# Altium schematic sheet 13 HDMI&EDP.SchDoc
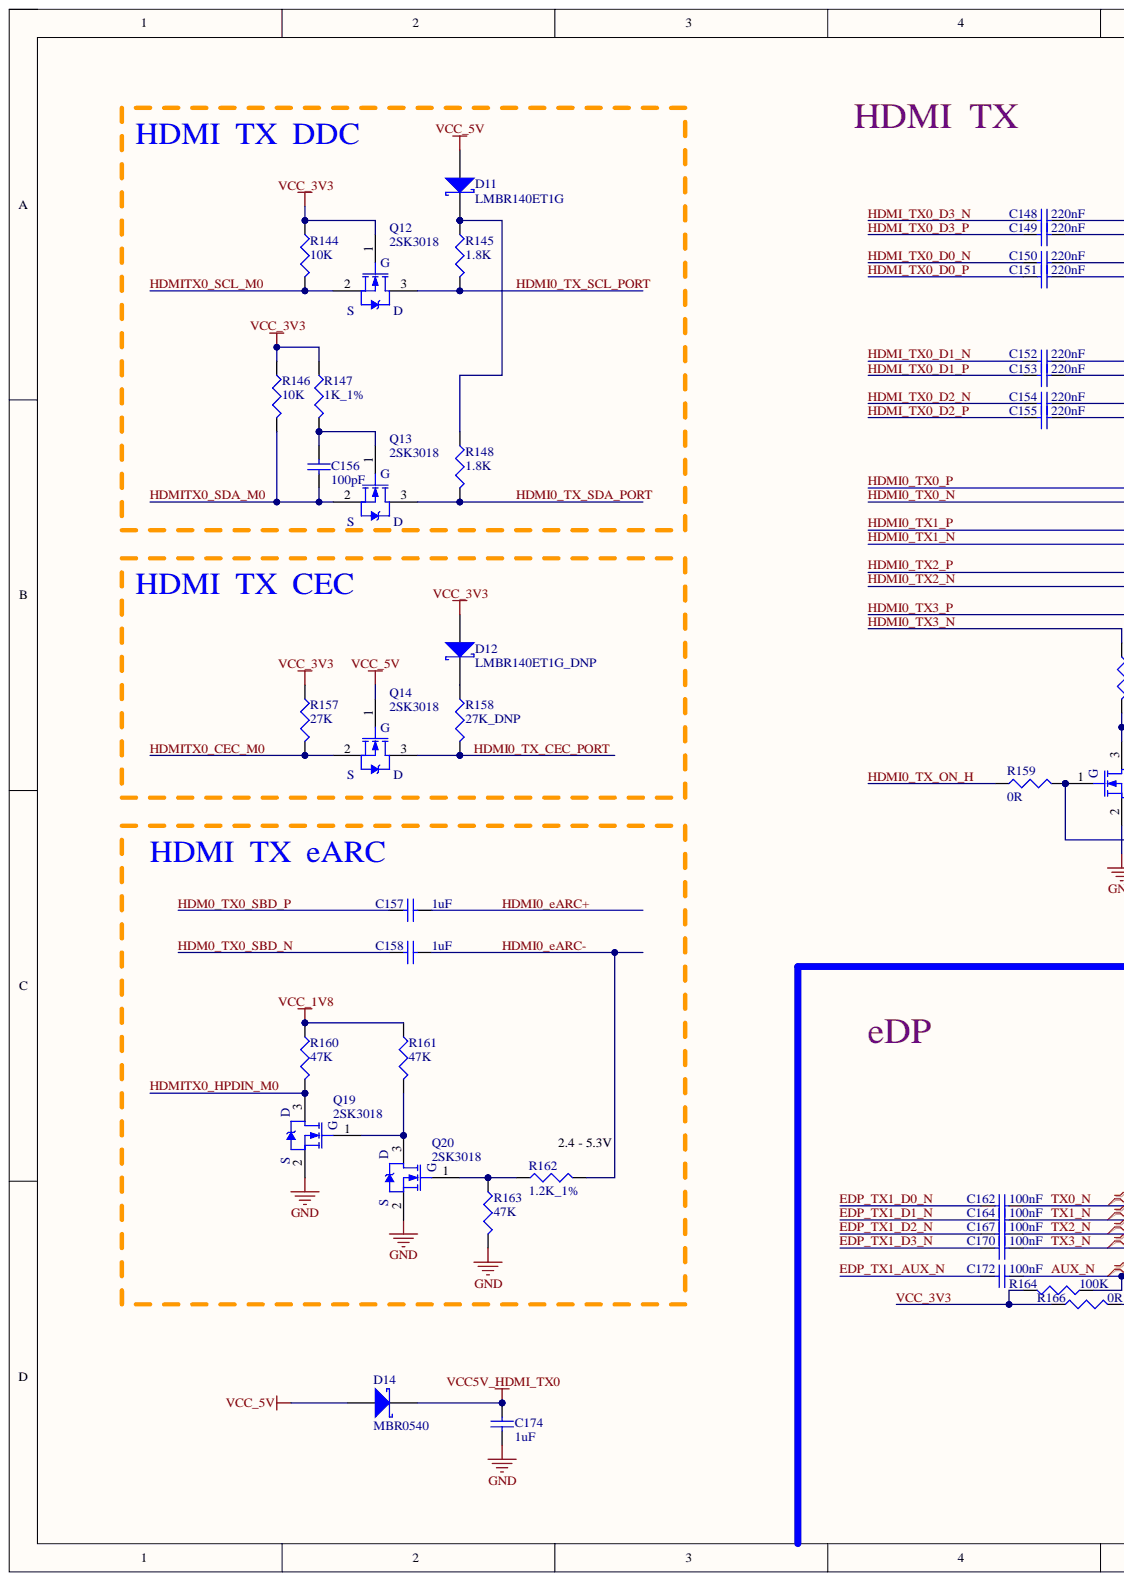
[diagram p0: 13 HDMI&EDP.SchDoc, left]
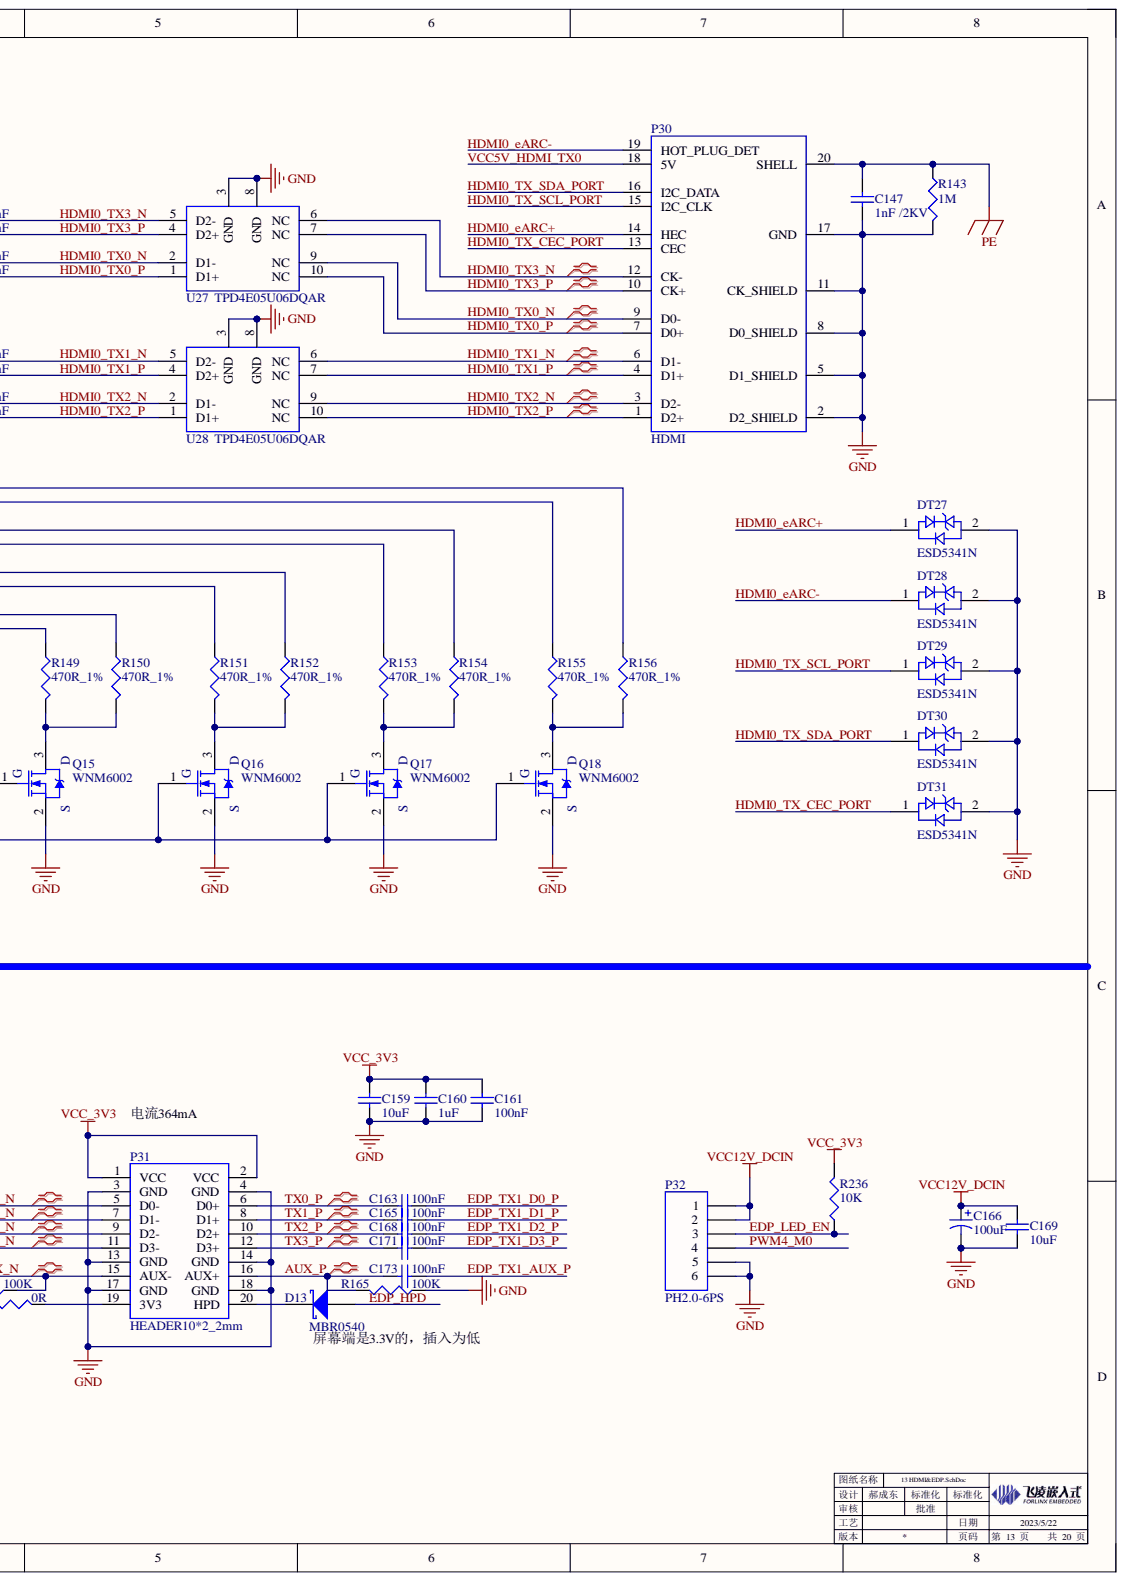
[diagram p1: 13 HDMI&EDP.SchDoc, right]

## Parts
C147  1nF /2KV  [1206C]
C148  220nF  [0201C]
C149  220nF  [0201C]
C150  220nF  [0201C]
C151  220nF  [0201C]
C152  220nF  [0201C]
C153  220nF  [0201C]
C154  220nF  [0201C]
C155  220nF  [0201C]
C156  100pF  [0402C]
C157  1uF  [0201C]
C158  1uF  [0201C]
C159  10uF  [0805C]
C160  1uF  [0402C]
C161  100nF  [0402C]
C162  100nF  [0402C]
C163  100nF  [0402C]
C164  100nF  [0402C]
C165  100nF  [0402C]
C166  100uF  [CAP SMT6.3X5.9]
C167  100nF  [0402C]
C168  100nF  [0402C]
C169  10uF  [1206C]
C170  100nF  [0402C]
C171  100nF  [0402C]
C172  100nF  [0402C]
C173  100nF  [0402C]
C174  1uF  [0402C]
D11  LMBR140ET1G  [SOD_323]
D12  LMBR140ET1G_DNP  [SOD_323]
D13  MBR0540  [SOD_123]
D14  MBR0540  [SOD_123]
DT27  ESD5341N  [ESD5341N]
DT28  ESD5341N  [ESD5341N]
DT29  ESD5341N  [ESD5341N]
DT30  ESD5341N  [ESD5341N]
DT31  ESD5341N  [ESD5341N]
P30  HDMI  [HDMI]
P31  HEADER10*2_2mm  [HEADER10*2_2.0_SPZ]
P32  PH2.0-6PS  [PH2.0_6P_V]
Q12  2SK3018  [SOT323]
Q13  2SK3018  [SOT323]
Q14  2SK3018  [SOT323]
Q15  WNM6002  [SOT323]
Q16  WNM6002  [SOT323]
Q17  WNM6002  [SOT323]
Q18  WNM6002  [SOT323]
Q19  2SK3018  [SOT323]
Q20  2SK3018  [SOT323]
R143  1M  [1206R]
R144  10K  [0402R]
R145  1.8K  [0402R]
R146  10K  [0402R]
R147  1K_1%  [0402R]
R148  1.8K  [0402R]
R149  470R_1%  [0201R]
R150  470R_1%  [0201R]
R151  470R_1%  [0201R]
R152  470R_1%  [0201R]
R153  470R_1%  [0201R]
R154  470R_1%  [0201R]
R155  470R_1%  [0201R]
R156  470R_1%  [0201R]
R157  27K  [0402R]
R158  27K_DNP  [0402R]
R159  0R  [0402R]
R160  47K  [0402R]
R161  47K  [0402R]
R162  1.2K_1%  [0402R]
R163  47K  [0402R]
R164  100K  [0402R]
R165  100K  [0402R]
R166  0R  [0603R]
R236  10K  [0402R]
U27  TPD4E05U06DQAR  [USON-10]
U28  TPD4E05U06DQAR  [USON-10]

## Nets
GND: C147.1 C159.1 C160.1 C161.1 C166.2 C169.1 C174.1 DT27.2 DT28.2 DT29.2 DT30.2 DT31.2 P30.2(D2_SHIELD) P30.5(D1_SHIELD) P30.8(D0_SHIELD) P30.11(CK_SHIELD) P30.17(GND) P31.3(GND) P31.4(GND) P31.13(GND) P31.14(GND) P31.17(GND) P31.18(GND) P32.5 P32.6 Q15.2 Q16.2 Q17.2 Q18.2 Q19.2 Q20.2 R143.2 R163.2 R165.1 U27.3(GND) U27.8(GND) U28.3(GND) U28.8(GND)
PE: C147.2 P30.20(SHELL) R143.1
VCC12V_DCIN: C166.1 C169.2 P32.1 P32.2
VCC_1V8: R160.1 R161.1
VCC_5V: D11.1 D14.1 Q14.1
AUX_N: C172.2 P31.15(AUX-) R164.1
AUX_P: C173.1 P31.16(AUX+) R165.2
EDP_HPD: D13.1
EDP_LED_EN: P32.3 R236.1
EDP_TX1_AUX_N: C172.1
EDP_TX1_AUX_P: C173.2
EDP_TX1_D0_N: C162.1
EDP_TX1_D0_P: C163.2
EDP_TX1_D1_N: C164.1
EDP_TX1_D1_P: C165.2
EDP_TX1_D2_N: C167.1
EDP_TX1_D2_P: C168.2
EDP_TX1_D3_N: C170.1
EDP_TX1_D3_P: C171.2
HDM0_TX0_SBD_N: C158.1
HDM0_TX0_SBD_P: C157.1
HDMI0_eARC+: C157.2 DT27.1 P30.14(HEC)
HDMI0_eARC-: C158.2 DT28.1 P30.19(HOT_PLUG_DET) R162.2
HDMI0_TX0_N: C150.2 P30.9(D0-) R155.1 U27.2(D1-) U27.9(NC)
HDMI0_TX0_P: C151.2 P30.7(D0+) R156.1 U27.1(D1+) U27.10(NC)
HDMI0_TX1_N: C152.2 P30.6(D1-) R153.1 U28.5(D2-) U28.6(NC)
HDMI0_TX1_P: C153.2 P30.4(D1+) R154.1 U28.4(D2+) U28.7(NC)
HDMI0_TX2_N: C154.2 P30.3(D2-) R151.1 U28.2(D1-) U28.9(NC)
HDMI0_TX2_P: C155.2 P30.1(D2+) R152.1 U28.1(D1+) U28.10(NC)
HDMI0_TX3_N: C148.2 P30.12(CK-) R149.1 U27.5(D2-) U27.6(NC)
HDMI0_TX3_P: C149.2 P30.10(CK+) R150.1 U27.4(D2+) U27.7(NC)
HDMI0_TX_CEC_PORT: DT31.1 P30.13(CEC) Q14.3 R158.2
HDMI0_TX_ON_H: R159.1
HDMI0_TX_SCL_PORT: DT29.1 P30.15(I2C_CLK) Q12.3 R145.2
HDMI0_TX_SDA_PORT: DT30.1 P30.16(I2C_DATA) Q13.3 R148.2
HDMI_TX0_D0_N: C150.1
HDMI_TX0_D0_P: C151.1
HDMI_TX0_D1_N: C152.1
HDMI_TX0_D1_P: C153.1
HDMI_TX0_D2_N: C154.1
HDMI_TX0_D2_P: C155.1
HDMI_TX0_D3_N: C148.1
HDMI_TX0_D3_P: C149.1
HDMITX0_CEC_M0: Q14.2 R157.2
HDMITX0_HPDIN_M0: Q19.3 R160.2
HDMITX0_SCL_M0: Q12.2 R144.2
HDMITX0_SDA_M0: C156.1 Q13.2 R146.2
PWM4_M0: P32.4
TX0_N: C162.2 P31.5(D0-)
TX0_P: C163.1 P31.6(D0+)
TX1_N: C164.2 P31.7(D1-)
TX1_P: C165.1 P31.8(D1+)
TX2_N: C167.2 P31.9(D2-)
TX2_P: C168.1 P31.10(D2+)
TX3_N: C170.2 P31.11(D3-)
TX3_P: C171.1 P31.12(D3+)
VCC5V_HDMI_TX0: C174.2 D14.2 P30.18(5V)
VCC_3V3: C159.2 C160.2 C161.2 D12.1 P31.1(VCC) P31.2(VCC) Q12.1 R144.1 R146.1 R147.1 R157.1 R164.2 R166.1 R236.2
NetC156_2: C156.2 Q13.1 R147.2
NetD11_2: D11.2 R145.1 R148.1
NetD12_2: D12.2 R158.1
NetD13_2: D13.2 P31.20(HPD)
NetP31_19: P31.19(3V3) R166.2
NetQ15_1: Q15.1 Q16.1 Q17.1 Q18.1 R159.2
NetQ15_3: Q15.3 R149.2 R150.2
NetQ16_3: Q16.3 R151.2 R152.2
NetQ17_3: Q17.3 R153.2 R154.2
NetQ18_3: Q18.3 R155.2 R156.2
NetQ19_1: Q19.1 Q20.3 R161.2
NetQ20_1: Q20.1 R162.1 R163.1

## Library data of the parts
C147 = 1110213001: Database Table Name: VALID$; 存货名称: 贴装高压电容; 安全库存: 116; 序号: 770; 旧编码: 010205013; 旧规格型号: 1206 1nF 2KV ±10％; 规格型号: 1206,1nF,2KV,±10％; Component Kind: Standard; LatestRevisionDate: 17-Jul-2002; LatestRevisionNote: Re-released for DXP Platform.; Library Name: Database_AD Library.DbLib; PackageDescription: Radial Cap, Thru-Hole; 2 Leads; 0.3 in Pin Spacing; PackageReference: RAD-0.3; Published: 8-Jun-2000; Signal Integrity: Cap; Simulation: CAP; Value: 1nF /2KV; 存货编码: 1110213001; Publisher: Altium Limited
C148-C155 = 1110201001: Database Table Name: VALID$; 存货名称: 贴装叠层陶瓷电容; 序号: 928; 规格型号: 0201,220nF,6.3V,±20％,X5R; Component Kind: Standard; LatestRevisionDate: 17-Jul-2002; LatestRevisionNote: Re-released for DXP Platform.; Library Name: Database_AD Library.DbLib; PackageDescription: Radial Cap, Thru-Hole; 2 Leads; 0.3 in Pin Spacing; PackageReference: RAD-0.3; Published: 8-Jun-2000; Signal Integrity: Cap; Simulation: CAP; Value: 220nF; 存货编码: 1110201001; Publisher: Altium Limited
C156 = 1110202016: Database Table Name: VALID$; 存货名称: 贴装叠层陶瓷电容; 序号: 587; 规格型号: 0402,100pF,50V,±5％,NPO; Component Kind: Standard; LatestRevisionDate: 17-Jul-2002; LatestRevisionNote: Re-released for DXP Platform.; Library Name: Database_AD Library.DbLib; PackageDescription: Radial Cap, Thru-Hole; 2 Leads; 0.3 in Pin Spacing; PackageReference: RAD-0.3; Published: 8-Jun-2000; Signal Integrity: Cap; Simulation: CAP; Value: 100pF; 存货编码: 1110202016; Publisher: Altium Limited
C157 C158 = 1110201015: Database Table Name: VALID$; 存货名称: 贴装叠层陶瓷电容; 规格型号: 0201,1uF,10V,±20％,X5R; Component Kind: Standard; LatestRevisionDate: 17-Jul-2002; LatestRevisionNote: Re-released for DXP Platform.; Library Name: Database_AD Library.DbLib; PackageDescription: Radial Cap, Thru-Hole; 2 Leads; 0.3 in Pin Spacing; PackageReference: RAD-0.3; Published: 8-Jun-2000; Signal Integrity: Cap; Simulation: CAP; Value: 1uF; 存货编码: 1110201015; Publisher: Altium Limited
C159 = 1110204010: Database Table Name: VALID$; 存货名称: 贴装叠层陶瓷电容; 序号: 745; 描述栏信息: 同010203007; 规格型号: 0805,10uF,10V,±10％,X7R; Component Kind: Standard; LatestRevisionDate: 17-Jul-2002; LatestRevisionNote: Re-released for DXP Platform.; Library Name: Database_AD Library.DbLib; PackageDescription: Radial Cap, Thru-Hole; 2 Leads; 0.3 in Pin Spacing; PackageReference: RAD-0.3; Published: 8-Jun-2000; Signal Integrity: Cap; Simulation: CAP; Value: 10uF; 存货编码: 1110204010; Publisher: Altium Limited
C160 C174 = 1110202021: Database Table Name: VALID$; 存货名称: 贴装叠层陶瓷电容; 序号: 600; 规格型号: 0402,1uF,16V,±10％,X5R; Component Kind: Standard; LatestRevisionDate: 17-Jul-2002; LatestRevisionNote: Re-released for DXP Platform.; Library Name: Database_AD Library.DbLib; PackageDescription: Radial Cap, Thru-Hole; 2 Leads; 0.3 in Pin Spacing; PackageReference: RAD-0.3; Published: 8-Jun-2000; Signal Integrity: Cap; Simulation: CAP; Value: 1uF; 存货编码: 1110202021; Publisher: Altium Limited
C161 = 1110202039: Database Table Name: VALID$; 存货名称: 贴装叠层陶瓷电容; 序号: 626; 规格型号: 0402,100nF,25V,±10％,X5R; Component Kind: Standard; LatestRevisionDate: 17-Jul-2002; LatestRevisionNote: Re-released for DXP Platform.; Library Name: Database_AD Library.DbLib; PackageDescription: Radial Cap, Thru-Hole; 2 Leads; 0.3 in Pin Spacing; PackageReference: RAD-0.3; Published: 8-Jun-2000; Signal Integrity: Cap; Simulation: CAP; Value: 100nF; 存货编码: 1110202039; Publisher: Altium Limited
C162-C165 C167 C168 C170-C173 = 1110202001: Database Table Name: VALID$; 存货名称: 贴装叠层陶瓷电容; 安全库存: 15263; 序号: 570; 旧编码: 010201001; 旧规格型号: 0402 100nF 16V ±10％ X7R; 规格型号: 0402,100nF,16V,±10％,X7R; Component Kind: Standard; LatestRevisionDate: 17-Jul-2002; LatestRevisionNote: Re-released for DXP Platform.; Library Name: Database_AD Library.DbLib; PackageDescription: Radial Cap, Thru-Hole; 2 Leads; 0.3 in Pin Spacing; PackageReference: RAD-0.3; Published: 8-Jun-2000; Signal Integrity: Cap; Simulation: CAP; Value: 100nF; 存货编码: 1110202001; Publisher: Altium Limited
C166 = 1110211005: Database Table Name: VALID$; 存货名称: 贴装铝电解电容; 序号: 861; 规格型号: 100uF,16V,6.3*5.9mm; Component Kind: Standard; LatestRevisionDate: 17-Jul-2002; LatestRevisionNote: Re-released for DXP Platform.; Library Name: Database_AD Library.DbLib; PackageDescription: Polarized Capacitor (Radial); 2 Leads; PackageReference: RB7.6-15; Published: 8-Jun-2000; Signal Integrity: Cap Pol; Simulation: CAP; Value: 100uF; 存货编码: 1110211005; Publisher: Altium Limited
C169 = 1110205010: Database Table Name: VALID$; 存货名称: 贴装叠层陶瓷电容; 序号: 768; 规格型号: 1206,10uF,25V,±10％,X5R; Component Kind: Standard; LatestRevisionDate: 17-Jul-2002; LatestRevisionNote: Re-released for DXP Platform.; Library Name: Database_AD Library.DbLib; PackageDescription: Radial Cap, Thru-Hole; 2 Leads; 0.3 in Pin Spacing; PackageReference: RAD-0.3; Published: 8-Jun-2000; Signal Integrity: Cap; Simulation: CAP; Value: 10uF; 存货编码: 1110205010; Publisher: Altium Limited
D11 D12 = 1110501064: Database Table Name: VALID$; 存货名称: 肖特基二极管; 规格型号: LMBR140ET1G,SOD323; Code_JEDEC: DO-214-AA; Component Kind: Standard; LatestRevisionDate: 17-Jul-2002; LatestRevisionNote: Re-released for DXP Platform.; Library Name: Database_AD Library.DbLib; PackageDescription: DO-214-AA/SMB; 2 C-Bend Leads; Body 5.3 x 3.6 mm, inc. leads (LxW); PackageReference: SMB; Published: 8-Jun-2000; Signal Integrity: D Schottky; Simulation: SKYDIODE; 存货编码: 1110501064; Publisher: Altium Limited
  D11: Value: LMBR140ET1G
  D12: Value: LMBR140ET1G_DNP
D13 = 1110501001: Database Table Name: VALID$; 存货名称: 肖特基二极管; 安全库存: 3000; 序号: 2480; 旧编码: 010601002; 旧规格型号: MBR0540 SOD123; 规格型号: MBR0540,SOD123; Code_JEDEC: DO-214-AA; Component Kind: Standard; LatestRevisionDate: 17-Jul-2002; LatestRevisionNote: Re-released for DXP Platform.; Library Name: Database_AD Library.DbLib; PackageDescription: DO-214-AA/SMB; 2 C-Bend Leads; Body 5.3 x 3.6 mm, inc. leads (LxW); PackageReference: SMB; Published: 8-Jun-2000; Signal Integrity: D Schottky; Simulation: SKYDIODE; Value: MBR0540; 存货编码: 1110501001; Publisher: Altium Limited
D14 = 1110501001: Database Table Name: VALID$; 存货名称: 肖特基二极管; 序号: 2480; 规格型号: MBR0540,SOD123; Code_JEDEC: DO-214-AA; Component Kind: Standard; LatestRevisionDate: 17-Jul-2002; LatestRevisionNote: Re-released for DXP Platform.; Library Name: Database_AD Library.DbLib; PackageDescription: DO-214-AA/SMB; 2 C-Bend Leads; Body 5.3 x 3.6 mm, inc. leads (LxW); PackageReference: SMB; Published: 8-Jun-2000; Signal Integrity: D Schottky; Simulation: SKYDIODE; Value: MBR0540; 存货编码: 1110501001; Publisher: Altium Limited
P30 = 1120202041: Database Table Name: VALID$; 存货名称: 插装HDMI座; 序号: 1427; 描述栏信息: FCI生产编号：10029449,001RLF  ，与1120202030通用; 规格型号: A型（替代1120202030）; Component Kind: Standard; Library Name: Database_AD Library.DbLib; Value: HDMI; 存货编码: 1120202041
P31 = HEADER10*2_2mm: 010402072; Component Kind: Standard; Library Name: Schlib1.SchLib; Value: HEADER10*2_2mm
P32 = 1120201009: Database Table Name: VALID$; 存货名称: 连接器; 安全库存: 392; 序号: 1263; 旧编码: 010402003; 旧规格型号: 公头 PH-2.0mm-6PS（与010402255是否为一个？）; 规格型号: PH,公头,6P,2.0mm,S; Component Kind: Standard; LatestRevisionDate: 17-Jul-2002; LatestRevisionNote: Re-released for DXP Platform.; Library Name: Database_AD Library.DbLib; Signal Integrity: Connector; Value: PH2.0-6PS; 存货编码: 1120201009; Publisher: Altium Limited
Q12-Q14 Q19 Q20 = 1110505016: Database Table Name: VALID$; Note: Pin 2 is electrically connected to pin 4.; 存货名称: 场效应管; 序号: 2672; 规格型号: 2SK3018,SOT-323,N沟道; Component Kind: Standard; DatasheetVersion: PD-9.814; LatestRevisionDate: 17-Jul-2002; LatestRevisionNote: Re-released for DXP Platform.; Library Name: Database_AD Library.DbLib; PackageDescription: TO, Thru-Hole, Vertical, Heatsink Mounted; 3 In-Line Leads; Pitch 2.54 mm; PackageReference: TO-220AB; PackageVersion: Feb-1997; Published: 8-Jun-2000; Simulation: IRF1010; VALUE: 2SK3018; 存货编码: 1110505016; Publisher: Altium Limited
Q15-Q18 = 1123: Database Table Name: VALID$; Note: Pin 2 is electrically connected to pin 4.; 存货名称: 场效应管; 规格型号: WNM6002,SOT-323,N沟道; Component Kind: Standard; DatasheetVersion: PD-9.814; LatestRevisionDate: 17-Jul-2002; LatestRevisionNote: Re-released for DXP Platform.; Library Name: Database_AD Library.DbLib; PackageDescription: TO, Thru-Hole, Vertical, Heatsink Mounted; 3 In-Line Leads; Pitch 2.54 mm; PackageReference: TO-220AB; PackageVersion: Feb-1997; Published: 8-Jun-2000; Simulation: IRF1010; VALUE: WNM6002; 存货编码: 1123; Publisher: Altium Limited
R143 = 1110105003: Database Table Name: VALID$; 存货名称: 贴装电阻; 序号: 501; 规格型号: 1206,1M,±5％; Component Kind: Standard; Library Name: Database_AD Library.DbLib; Signal Integrity: Res; Simulation: RESISTOR; Value: 1M; 存货编码: 1110105003
R144 R146 R236 = 1110102007: Database Table Name: VALID$; 存货名称: 贴装电阻; 序号: 17; 规格型号: 0402,10K,±5％; Component Kind: Standard; Library Name: Database_AD Library.DbLib; Signal Integrity: Res; Simulation: RESISTOR; Value: 10K; 存货编码: 1110102007
R145 R148 = 1110102185: Database Table Name: VALID$; 存货名称: 贴装电阻; 描述栏信息: 厚声; 规格型号: 0402,1.8K,±5％; Component Kind: Standard; Library Name: Database_AD Library.DbLib; Signal Integrity: Res; Simulation: RESISTOR; Value: 1.8K; 存货编码: 1110102185
R147 = 1110102011: Database Table Name: VALID$; 存货名称: 贴装电阻; 序号: 21; 规格型号: 0402,1K,±1％; Component Kind: Standard; Library Name: Database_AD Library.DbLib; Signal Integrity: Res; Simulation: RESISTOR; Value: 1K_1%; 存货编码: 1110102011
R149-R156 = 1110101007: Database Table Name: VALID$; 存货名称: 贴装电阻; 规格型号: 0201,470Ω,±1％; Component Kind: Standard; Library Name: Database_AD Library.DbLib; Signal Integrity: Res; Simulation: RESISTOR; Value: 470R_1%; 存货编码: 1110101007
R157 R158 = 1110102085: Database Table Name: VALID$; 存货名称: 贴装电阻; 序号: 119; 规格型号: 0402,27K,±5％; Component Kind: Standard; Library Name: Database_AD Library.DbLib; Signal Integrity: Res; Simulation: RESISTOR; 存货编码: 1110102085
  R157: Value: 27K
  R158: Value: 27K_DNP
R159 = 1110102001: Database Table Name: VALID$; 存货名称: 贴装电阻; 序号: 10; 规格型号: 0402,0Ω,±5％; Component Kind: Standard; Library Name: Database_AD Library.DbLib; Signal Integrity: Res; Simulation: RESISTOR; Value: 0R; 存货编码: 1110102001
R160 R161 R163 = 1110102040: Database Table Name: VALID$; 存货名称: 贴装电阻; 序号: 53; 规格型号: 0402,47K,±5％; Component Kind: Standard; Library Name: Database_AD Library.DbLib; Signal Integrity: Res; Simulation: RESISTOR; Value: 47K; 存货编码: 1110102040
R162 = 1110102012: Database Table Name: VALID$; 存货名称: 贴装电阻; 序号: 22; 规格型号: 0402,1.2K,±1％; Component Kind: Standard; Library Name: Database_AD Library.DbLib; Signal Integrity: Res; Simulation: RESISTOR; Value: 1.2K_1%; 存货编码: 1110102012
R164 R165 = 1110102004: Database Table Name: VALID$; 存货名称: 贴装电阻; 序号: 14; 规格型号: 0402,100K,±5％; Component Kind: Standard; Library Name: Database_AD Library.DbLib; Signal Integrity: Res; Simulation: RESISTOR; Value: 100K; 存货编码: 1110102004
R166 = 1110103004: Database Table Name: VALID$; 存货名称: 贴装电阻; 安全库存: 3819; 序号: 172; 旧编码: 010102005; 旧规格型号: 0603-0Ω ±5％; 规格型号: 0603,0Ω,±5％; Component Kind: Standard; Library Name: Database_AD Library.DbLib; Signal Integrity: Res; Simulation: RESISTOR; Value: 0R; 存货编码: 1110103004
U27 U28 = 1110503037: Database Table Name: VALID$; 存货名称: TVS管; 规格型号: TPD4E05U06DQAR,USON; Component Kind: Standard; Library Name: Database_AD Library.DbLib; Value: TPD4E05U06DQAR; 存货编码: 1110503037
DT27-DT31 = ESD5341N: Component Kind: Standard; Library Name: OK3588-C V1.1.SchLib
